# Altium schematic sheet clock.SchDoc
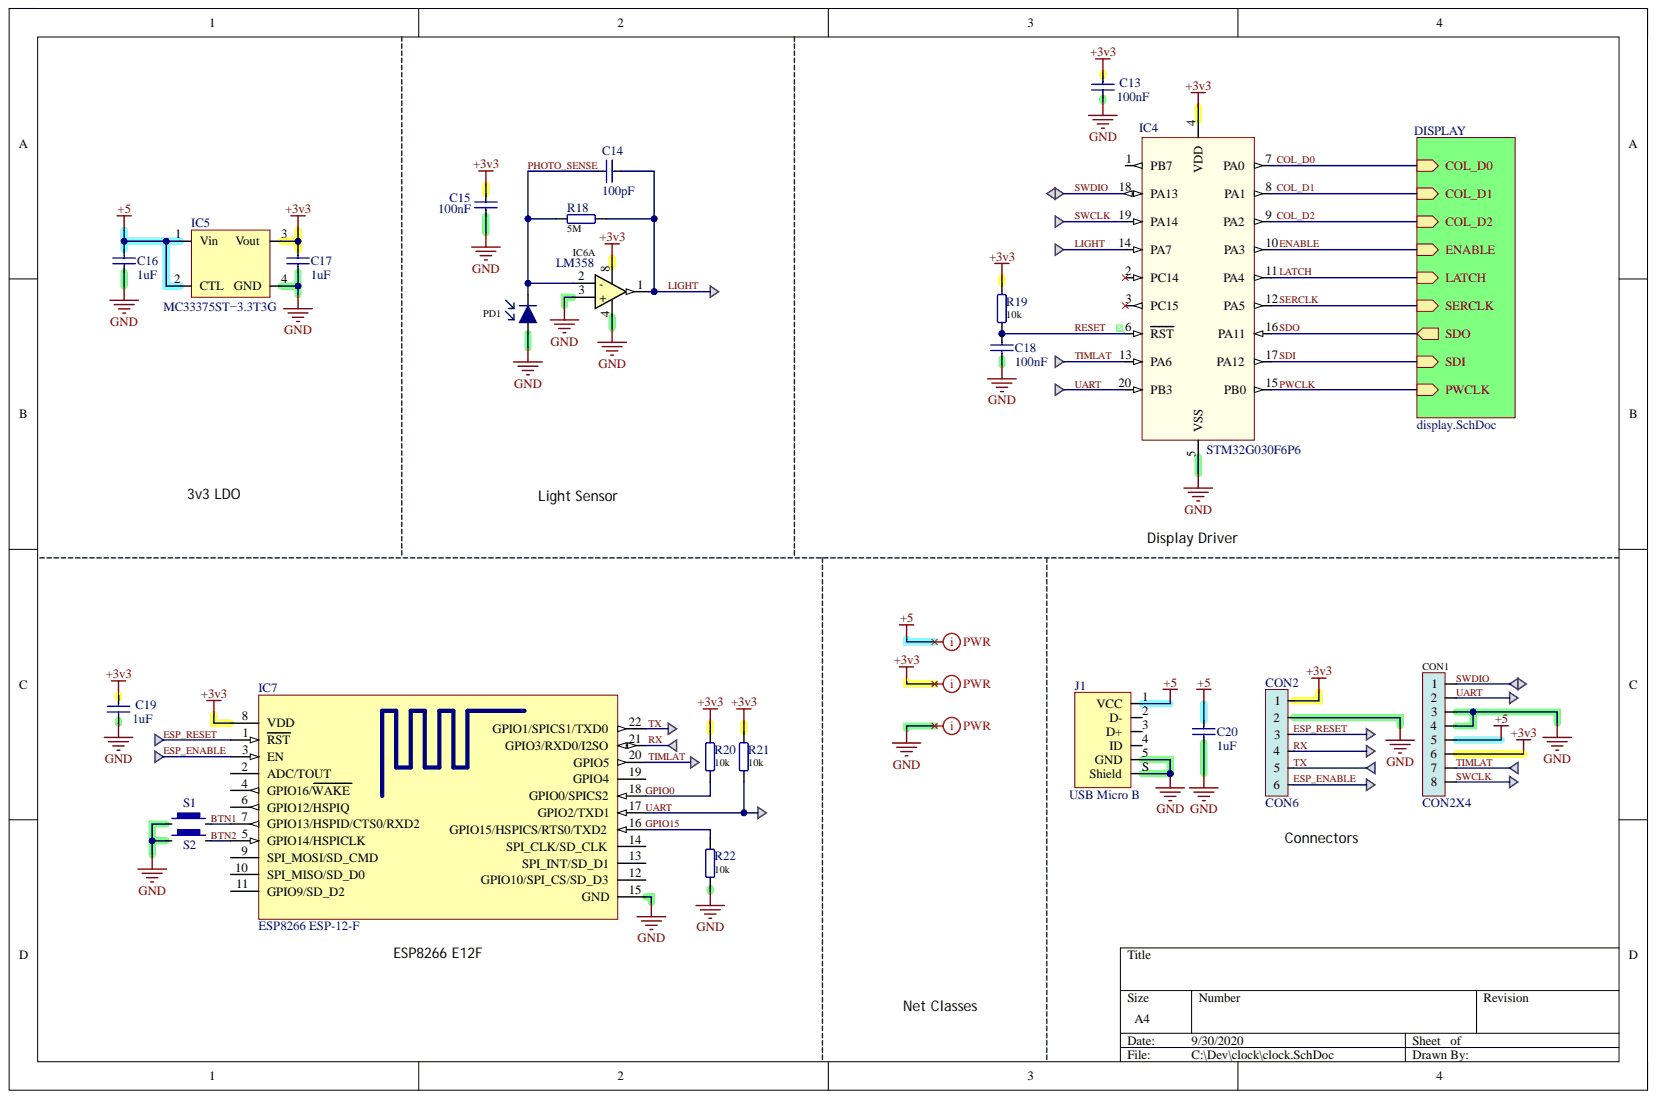
[diagram p0: clock.SchDoc]

## Parts
C13  value 100nF  [CAPC_0603_1608X08L]  (hidden comment: Cap)
C14  value 100pF  [CAPC_0603_1608X08L]  (hidden comment: Cap)
C15  value 100nF  [CAPC_0603_1608X08L]  (hidden comment: Cap)
C16  value 1uF  [CAPC_0603_1608X08L]  (hidden comment: Cap)
C17  value 1uF  [CAPC_0603_1608X08L]  (hidden comment: Cap)
C18  value 100nF  [CAPC_0603_1608X08L]  (hidden comment: Cap)
C19  value 1uF  [CAPC_0603_1608X08L]  (hidden comment: Cap)
C20  value 1uF  [CAPC_1206_3216X16L]  (hidden comment: Cap)
CON1  CON2X4  [CON2X4]  (no BOM)
CON2  CON6  [CON2X3]  (no BOM)
IC4  STM32G030F6P6  [TSOP65P630X120-20L]
IC5  MC33375ST−3.3T3G  [SOT230P700X180-4N]
IC6  LM358  [TSOP65P640X120-8L]  (part A)
IC7  ESP8266 ESP-12-F  [ESP8266 ESP-12-E]
J1  USB Micro B  [USB 1051330011]
PD1  SFH203  [BP 104 S]  (value not drawn)
R18  value 5M  [RESC1608X03L]  (hidden comment: RES0603)
R19  value 10k  [RESC1608X03L]  (hidden comment: RES0603)
R20  value 10k  [RESC1608X03L]  (hidden comment: RES0603)
R21  value 10k  [RESC1608X03L]  (hidden comment: RES0603)
R22  value 10k  [RESC1608X03L]  (hidden comment: RES0603)
S1  4-1437565-1  [EVQP7C01P]  (value not drawn)
S2  4-1437565-1  [EVQP7C01P]  (value not drawn)

## Nets
+3v3: C13.2 C15.2 C17.2 C19.2 CON1.6 CON2.1 IC4.4(VDD) IC5.3(Vout) IC6.8 IC7.8(VDD) R19.2 R20.1 R21.1
+5: C16.2 C20.2 CON1.5 IC5.1(Vin) IC5.2(CTL) J1.1(VCC)
GND: C13.1 C15.1 C16.1 C17.1 C18.1 C19.1 C20.1 CON1.3 CON1.4 CON2.2 IC4.5(VSS) IC5.4(GND) IC6.3(+) IC6.4 IC7.15(GND) J1.5(GND) J1.S(Shield) PD1.2 R22.2 S1.1 S2.1
BTN1: IC7.7(GPIO13/HSPID/CTS0/RXD2) S1.2
BTN2: IC7.5(GPIO14/HSPICLK) S2.2
COL_D0: IC4.7(PA0)
COL_D1: IC4.8(PA1)
COL_D2: IC4.9(PA2)
ENABLE: IC4.10(PA3)
ESP_ENABLE: CON2.6 IC7.3(EN)
ESP_RESET: CON2.3 IC7.1(RST)
GPIO0: IC7.18(GPIO0/SPICS2) R20.2
GPIO15: IC7.16(GPIO15/HSPICS/RTS0/TXD2) R22.1
LATCH: IC4.11(PA4)
LIGHT: C14.2 IC4.14(PA7) IC6.1 R18.1
PHOTO_SENSE: C14.1 IC6.2(-) PD1.1 R18.2
PWCLK: IC4.15(PB0)
RESET: C18.2 IC4.6(RST) R19.1
RX: CON2.4 IC7.21(GPIO3/RXD0/I2SO)
SDI: IC4.17(PA12)
SDO: IC4.16(PA11)
SERCLK: IC4.12(PA5)
SWCLK: CON1.8 IC4.19(PA14)
SWDIO: CON1.1 IC4.18(PA13)
TIMLAT: CON1.7 IC4.13(PA6) IC7.20(GPIO5)
TX: CON2.5 IC7.22(GPIO1/SPICS1/TXD0)
UART: CON1.2 IC4.20(PB3) IC7.17(GPIO2/TXD1) R21.2
NetIC4_1: IC4.1(PB7)
NetIC4_2: IC4.2(PC14)
NetIC4_3: IC4.3(PC15)
NetIC7_2: IC7.2(ADC/TOUT)
NetIC7_4: IC7.4(GPIO16/WAKE)
NetIC7_6: IC7.6(GPIO12/HSPIQ)
NetIC7_9: IC7.9(SPI_MOSI/SD_CMD)
NetIC7_10: IC7.10(SPI_MISO/SD_D0)
NetIC7_11: IC7.11(GPIO9/SD_D2)
NetIC7_12: IC7.12(GPIO10/SPI_CS/SD_D3)
NetIC7_13: IC7.13(SPI_INT/SD_D1)
NetIC7_14: IC7.14(SPI_CLK/SD_CLK)
NetIC7_19: IC7.19(GPIO4)
NetJ1_2: J1.2(D-)
NetJ1_3: J1.3(D+)
NetJ1_4: J1.4(ID)

## Library data of the parts
C13-C20 = Cap: Capacitor; Component Kind: Standard; LatestRevisionDate: 17-Jul-2002; LatestRevisionNote: Re-released for DXP Platform.; Library Name: clock.SchLib; PackageDescription: Radial Cap, Thru-Hole; 2 Leads; 0.3 in Pin Spacing; PackageReference: RAD-0.3; Published: 8-Jun-2000; Publisher: Altium Limited
CON1 = CON2X4: Header 4 way; Library Name: clock.SchLib
CON2 = CON6: Debug header 6 way; Library Name: clock.SchLib
IC5 = MC33375ST−3.3T3G: 3.3v LDO; Component Kind: Standard; Library Name: clock.SchLib
IC6 = LM358: Dual opamp; Component Kind: Standard; Library Name: clock.SchLib
J1 = USB 2.0 Type B Micro: Component Kind: Standard; Library Name: clock.SchLib
PD1 = SFH203: Photodiode; Component Kind: Standard; Library Name: clock.SchLib
R18-R22 = RES0603: Chip Resistor; Component Kind: Standard; Library Name: clock.SchLib
S1 S2 = 4-1437565-1: 6x6mm SMD tactile switch,5mm H 1.6N Black Button Tactile Switch, SPST-NO 0.05 A@ 12 V dc 1.4mm; Manufacturer_Name: TE Connectivity; Allied_Number: R1117787; Component Kind: Standard; Datasheet Link: http://uk.rs-online.com/web/p/products/1616046; Library Name: clock.SchLib; Manufacturer_Part_Number: 4-1437565-1; RS Part Number: 1616046
IC4 = STM32G030F6P6: Component Kind: Standard; Library Name: clock.SchLib
IC7 = ESP8266 ESP-12-F: Component Kind: Standard; Description: ESP8266 ESP-12-F; Library Name: clock.SchLib
